# Altium schematic sheet Entradas.SchDoc(Entradas)
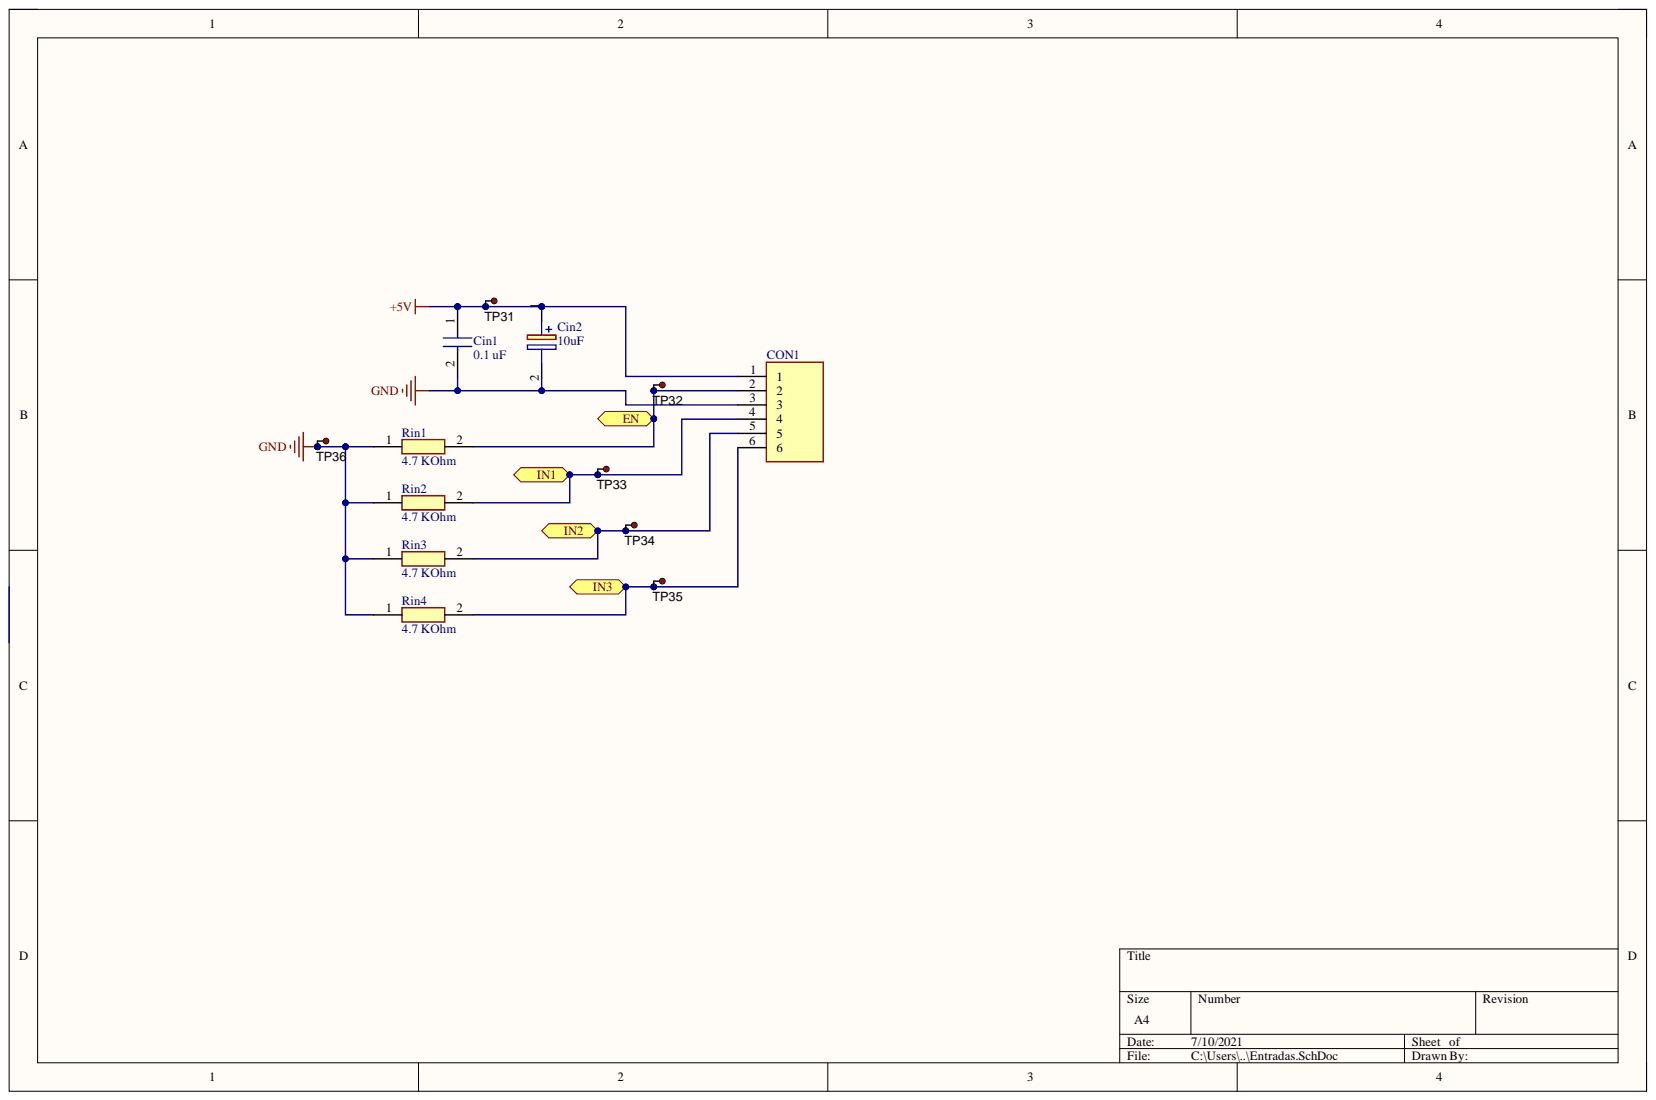
[diagram p0: Entradas.SchDoc]

## Parts
Cin1  0.1 uF  [CAPC2012X94N]
Cin2  10uF  [CAPPM3216X180N - duplicate]
CON1  2350513-6  [23505136]  (value not drawn)
Rin1  4.7 KOhm  [RESC1608X55N - duplicate]
Rin2  4.7 KOhm  [RESC1608X55N - duplicate]
Rin3  4.7 KOhm  [RESC1608X55N - duplicate]
Rin4  4.7 KOhm  [RESC1608X55N - duplicate]
TP31  5010  [Keystone5010]  (value not drawn)
TP32  5010  [Keystone5010]  (value not drawn)
TP33  5010  [Keystone5010]  (value not drawn)
TP34  5010  [Keystone5010]  (value not drawn)
TP35  5010  [Keystone5010]  (value not drawn)
TP36  5010  [Keystone5010]  (value not drawn)

## Ports
EN, IN1, IN2, IN3

## Nets
+5V: Cin1.1 Cin2.1 CON1.1 TP31.1
GND: Cin1.2 Cin2.2 CON1.3 Rin1.1 Rin2.1 Rin3.1 Rin4.1 TP36.1
EN: CON1.2 Rin1.2 TP32.1 ; port EN
IN1: CON1.4 Rin2.2 TP33.1 ; port IN1
IN2: CON1.5 Rin3.2 TP34.1 ; port IN2
IN3: CON1.6 Rin4.2 TP35.1 ; port IN3

## Library data of the parts
Cin1 = 0805YC104KAT2A: Multilayer Ceramic Capacitors MLCC - SMD/SMT 16V .1uF X7R 0805 10%; Manufacturer: Kyocera AVX; Manufacturer_Name: AVX; Capacitance: 100nF; Dissipation Factor: 12.5%; Insulation Resistance: 100GR; Arrow Part Number: 0805YC104KAT2A; Arrow Price/Stock: https://www.arrow.com/en/products/0805yc104kat2a/avx; Case Code (Imperial): 0805; Case Code (Metric): 2012; Case/Package: 0805; Category: Ceramic Capacitors; Component Kind: Standard; ComponentLink1Description: https://datasheet.ciiva.com/206/cx7r-206011.pdf?src-supplier=Digi-Key; ComponentLink1URL: https://datasheet.ciiva.com/206/cx7r-206011.pdf?src-supplier=Digi-Key; ComponentLink2Description: https://datasheet.ciiva.com/5418/cx7r-5418479.pdf?src-supplier=Mouser; ComponentLink2URL: https://datasheet.ciiva.com/5418/cx7r-5418479.pdf?src-supplier=Mouser; ComponentLink3Description: https://datasheet.ciiva.com/2988/cx7r-2988050.pdf?src-supplier=Avnet; ComponentLink3URL: https://datasheet.ciiva.com/2988/cx7r-2988050.pdf?src-supplier=Avnet; ComponentLink4Description: https://datasheet.ciiva.com/14906/cx7r-14906278.pdf?src-supplier=Digi-Key; ComponentLink4URL: https://datasheet.ciiva.com/14906/cx7r-14906278.pdf?src-supplier=Digi-Key; ComponentLink5Description: https://datasheet.ciiva.com/15212/getdatasheetpartid-150472-15212621.pdf?src-supplier=Verical; ComponentLink5URL: https://datasheet.ciiva.com/15212/getdatasheetpartid-150472-15212621.pdf?src-supplier=Verical; ComponentLink6Description: https://datasheet.ciiva.com/28613/1679506-28613143.pdf?src-supplier=Element14; ComponentLink6URL: https://datasheet.ciiva.com/28613/1679506-28613143.pdf?src-supplier=Element14; ComponentLink7Description: https://datasheet.ciiva.com/16623/cx7r-16623414.pdf?src-supplier=Avnet+Europe; ComponentLink7URL: https://datasheet.ciiva.com/16623/cx7r-16623414.pdf?src-supplier=Avnet+Europe; Construction: Flat; Datasheet Link: https://spicat.avx.com/product/mlcc/chartview/0805YC104KAT2A/DataSheet/X7R; Depth: 1.25mm; Dielectric: X7R; Height: 0.94mm; Lead Free: Lead Free; Length: 2.01mm; Manufacturer Part Number: 0805YC104KAT2A; Manufacturer_Part_Number: 0805YC104KAT2A; Max Operating Temperature: 125°C; Min Operating Temperature: -55°C; Mount: Surface Mount; Mouser Part Number: 581-0805YC104K; Mouser Price/Stock: https://www.mouser.co.uk/ProductDetail/AVX/0805YC104KAT2A?qs=0j3vzYx9OgS3bRufCc82qg%3D%3D; Number of Pins: 2; Package Quantity: 1; Packaging: Tape and Reel; Pricing 1: 1=0.30000, 10=0.20700, 50=0.12420, 100=0.10490, 500=0.07176, 1000=0.06348 (USD); Radiation Hardening: No; REACH SVHC: No SVHC; RoHS: Yes; RoHS Compliant: Yes; Series: -; Stock 1: 61419; Supplier 1: Digi-Key; Supplier Part Number 1: 478-7961-1-ND; Termination: SMD/SMT; Thickness: 0.037inch; Tolerance: 10%; Voltage Rating: 16V; Voltage Rating (DC): 16V; Width: 0.049inch
Cin2 = T494A106M020AT: Cap Tant Solid 10uF 20V A CASE 20% (3.2 X 1.6 X 1.6mm) SMD 3216-18 3 Ohm 125C T/R; Manufacturer: KEMET; Dissipation Factor: 10%; ESR (Equivalent Series Resistance): 3R; Leakage Current: 2uA; Life (Hours): 2000Hour; Polarity: Polar; Ripple Current: 158mA; Capacitance: 10uF; Case Code (Imperial): 1206; Case Code (Metric): 3216; Case/Package: 1206; Category: Tantalum Capacitors; Component Kind: Standard; ComponentLink1Description: https://datasheet.ciiva.com/22691/1778347-22691442.pdf?src-supplier=Element14; ComponentLink1URL: https://datasheet.ciiva.com/22691/1778347-22691442.pdf?src-supplier=Element14; ComponentLink2Description: https://datasheet.ciiva.com/258/kem-t2008-t494-258774.pdf?src-supplier=Digi-Key; ComponentLink2URL: https://datasheet.ciiva.com/258/kem-t2008-t494-258774.pdf?src-supplier=Digi-Key; ComponentLink3Description: https://datasheet.ciiva.com/258/kem-t2008-t494-258775.pdf?src-supplier=Digi-Key; ComponentLink3URL: https://datasheet.ciiva.com/258/kem-t2008-t494-258775.pdf?src-supplier=Digi-Key; ComponentLink4Description: https://datasheet.ciiva.com/258/kem-t2008-t494-258776.pdf?src-supplier=Digi-Key; ComponentLink4URL: https://datasheet.ciiva.com/258/kem-t2008-t494-258776.pdf?src-supplier=Digi-Key; Construction: Flat; Datasheet Link: https://componentsearchengine.com/Datasheets/2/T494A106M020AT.pdf; Depth: 1.6mm; Features: General Purpose; Height: 1.8mm; Height - Seated (Max): 0.071inch; Length: 3.2mm; Manufacturer Part Number: T494A106M020AT; Manufacturer_Name: Kemet; Manufacturer_Part_Number: T494A106M020AT; Max Operating Temperature: 125°C; Min Operating Temperature: -55°C; Mount: Surface Mount; Package Quantity: 1; Packaging: Tape and Reel; Pricing 1: 1=0.85000, 10=0.64900, 50=0.53900, 100=0.46420, 500=0.35936, 1000=0.30946 (USD); Radiation Hardening: No; REACH SVHC: No SVHC; RoHS: Yes; RoHS Compliant: Yes; Series: T494; Stock 1: 10000; Supplier 1: Digi-Key; Supplier Part Number 1: 399-5157-1-ND; Termination: SMD/SMT; Tolerance: 20%; Voltage Rating: 20V; Voltage Rating (DC): 20V; Width: 1.6mm; Library Name: sch jasiel.SchLib
CON1 = 2350513-6: Connector; Manufacturer: TE Connectivity; Component Kind: Standard; Datasheet Link: https://componentsearchengine.com/Datasheets/1/2350513-6.pdf; Height: 8.6mm; Manufacturer Part Number: 2350513-6; Manufacturer_Name: TE Connectivity; Manufacturer_Part_Number: 2350513-6; Pricing 1: 1=1.16000, 10=0.81200, 25=0.75720, 50=0.68740, 100=0.68430, 250=0.57788, 500=0.54748, 1000=0.48664, 5000=0.39540 (USD); RoHS: Yes; Stock 1: 2983; Supplier 1: Digi-Key; Supplier Part Number 1: A145376-ND; Library Name: sch jasiel.SchLib
Rin1-Rin4 = ESR03EZPJ472: Manufacturer: Rohm; Manufacturer_Name: ROHM Semiconductor; Max Power Dissipation: 250mW; Resistance: 4.7kR; Temperature Coefficient: 200ppm/°C; Tolerance: 5%; Case Code (Imperial): 0603; Case/Package: 0603; Category: Chip SMD Resistors; Component Kind: Standard; ComponentLink1Description: https://datasheet.ciiva.com/2468/esr-2468934.pdf?src-supplier=Digi-Key; ComponentLink1URL: https://datasheet.ciiva.com/2468/esr-2468934.pdf?src-supplier=Digi-Key; Datasheet Link: http://www.rohm.com/web/global/datasheet/ESR01MZPF/esr-e; Description: ESR03EZPJ472; Features: Automotive AEC-Q200; Height: 0.55mm; Length: 0.063inch; Manufacturer Part Number: ESR03EZPJ472; Manufacturer_Part_Number: ESR03EZPJ472; Max Operating Temperature: 155°C; Min Operating Temperature: -55°C; Number of Terminations: 2; Package Quantity: 5000; Packaging: Tape and Reel; Pricing 1: 1=0.10000, 10=0.08200, 100=0.03160, 1000=0.01392, 2500=0.01202 (USD); Resistor Type: Thick Film; RoHS: Yes; RoHS Compliant: Yes; Series: ESR; Stock 1: 85174; Supplier 1: Digi-Key; Supplier Part Number 1: RHM4.7KDCT-ND; Width: 0.031inch; Library Name: sch jasiel.SchLib
TP31-TP36 = 5010: Test Point, Multipurpose, Red, TH; Manufacturer: Keystone; CompType: Test Point Loop; ContactFinish: Silver; Color: Red; Component Kind: Standard; ComponentLink1Description: Datasheet URL; ComponentLink1URL: http://www.keyelco.com/userAssets/file/M60-2p60.pdf; LatestRevisionDate: 2016-02-11; MountType: TH; PackageReference: Red Multipurpose Testpoint; PartNumber: 5010; RoHS: Y; Supplier 1: Digi-Key; Supplier 2: Mouser; Supplier Part Number 1: 36-5010-ND; Supplier Part Number 2: 534-5010; SymbolVersion: 2008-04-04; Library Name: sch jasiel.SchLib; Publisher: Texas Instruments
